# Altium schematic sheet CDU_Peripherals.SchDoc(CDU_Peripherals)
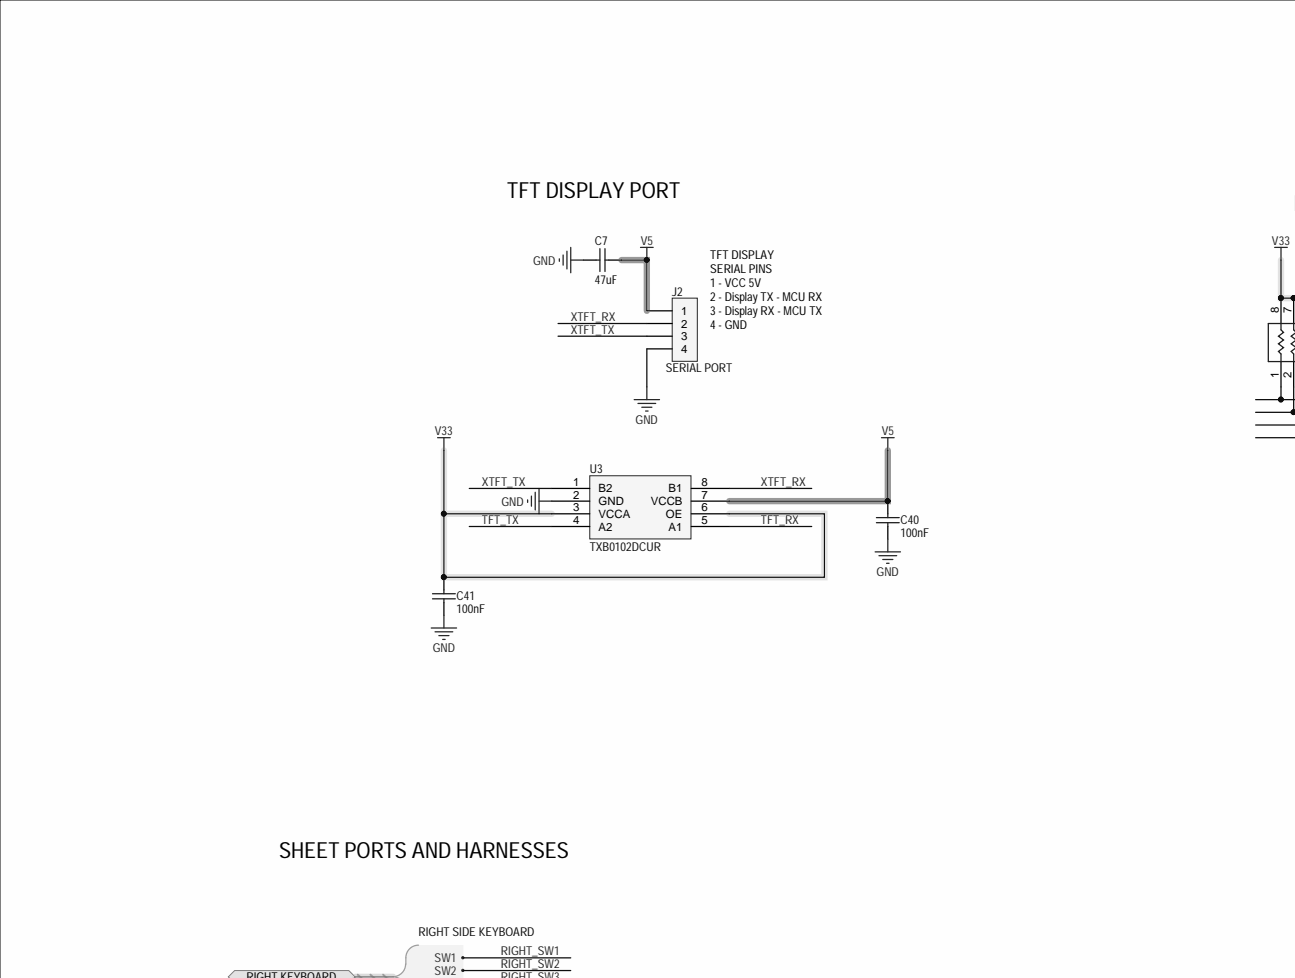
[diagram p0: CDU_Peripherals.SchDoc, top left]
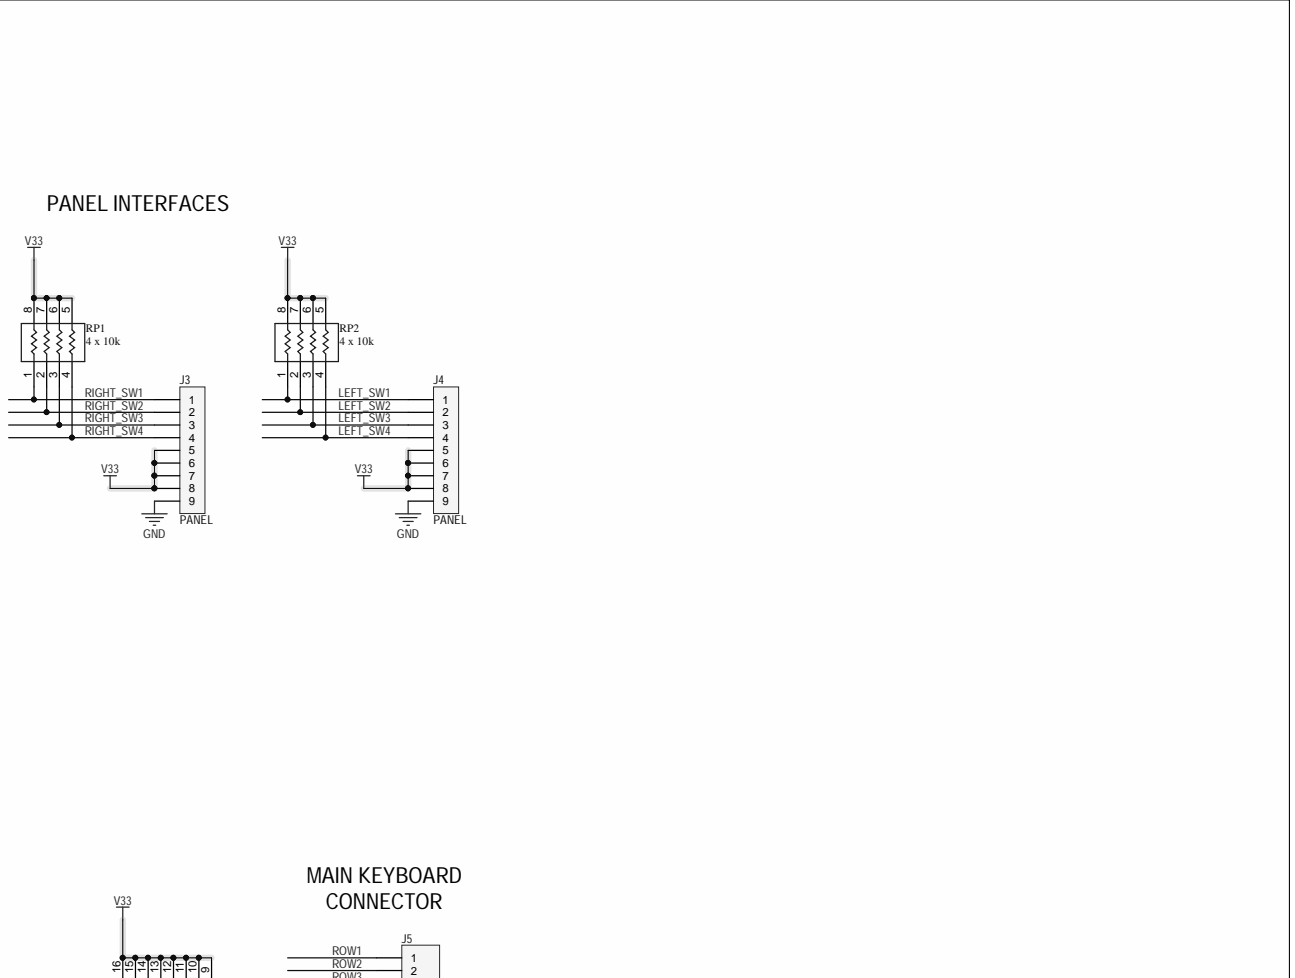
[diagram p1: CDU_Peripherals.SchDoc, top right]
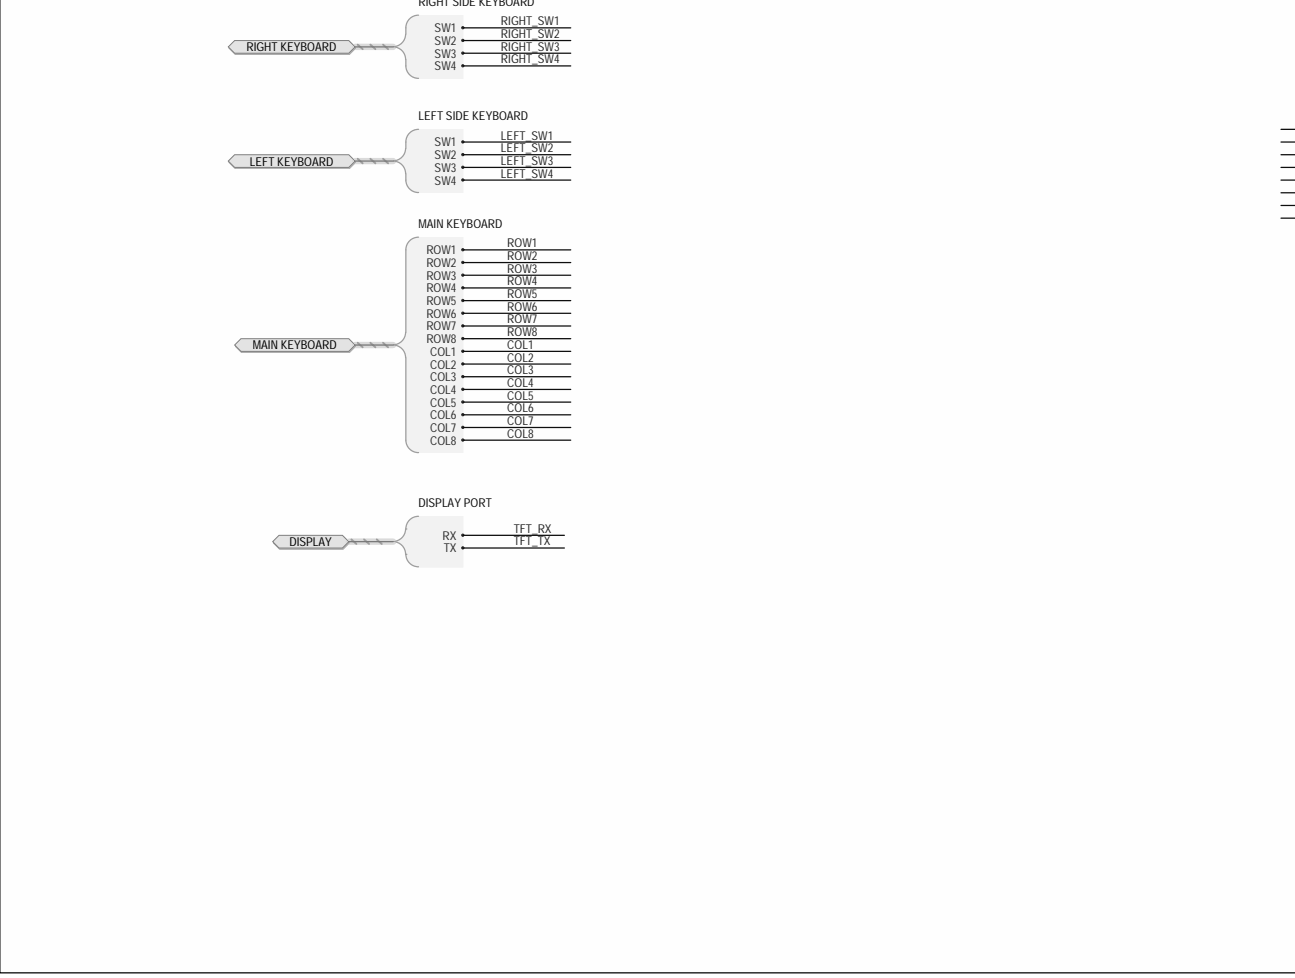
[diagram p2: CDU_Peripherals.SchDoc, bottom left]
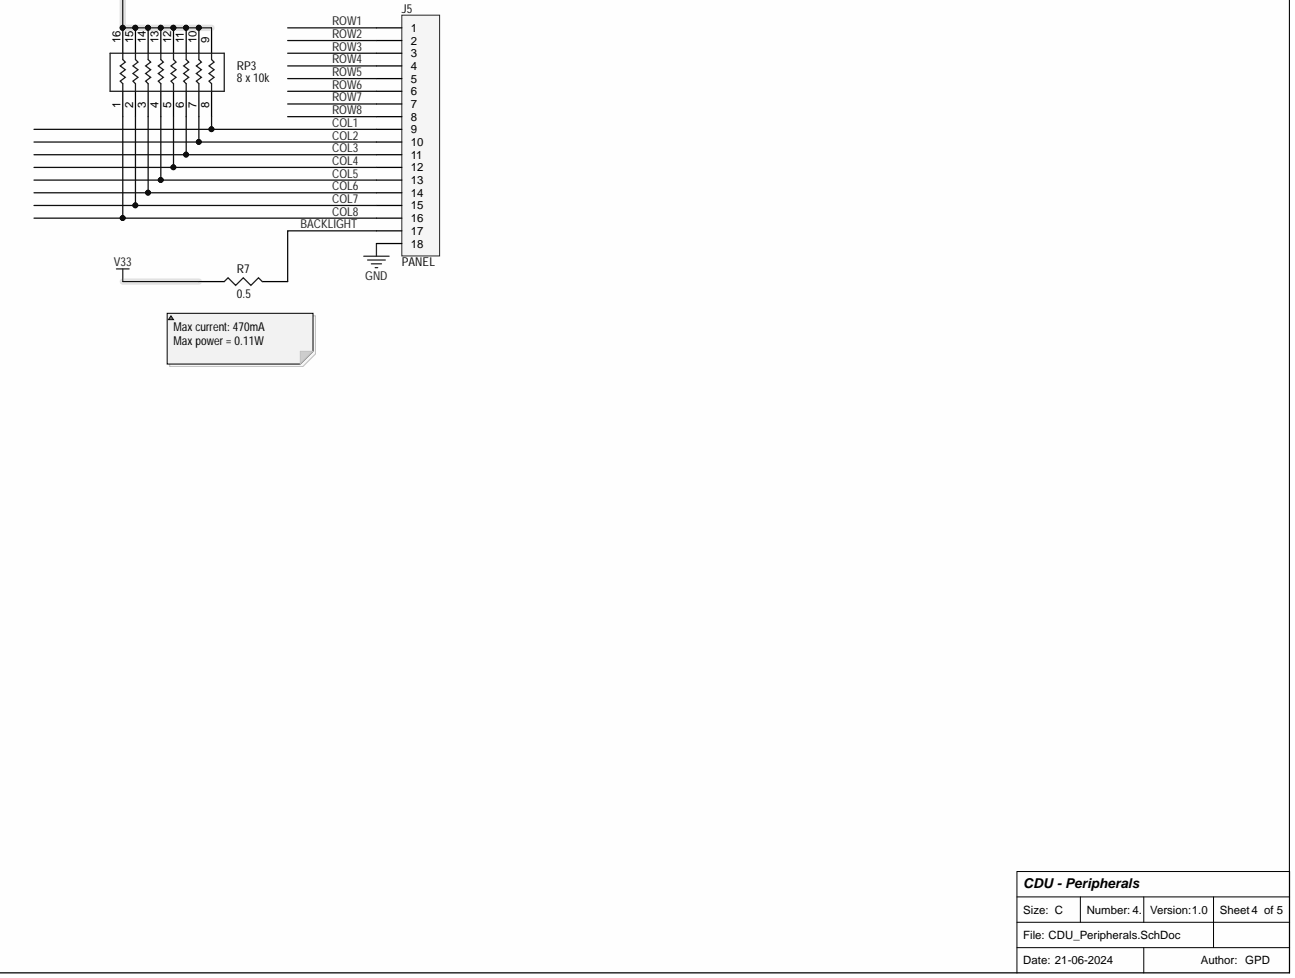
[diagram p3: CDU_Peripherals.SchDoc, bottom right]

## Parts
C7  47uF  [C1210]
C40  100nF  [C0402]
C41  100nF  [C0402]
J2  SERIAL PORT  [HDR1X4]
J3  PANEL  [JST-9P]
J4  PANEL  [JST-9P]
J5  PANEL  [HDR1X18]
R7  0.5  [R1206]
RP1  4 x 10k  [RESPACK08_0603]
RP2  4 x 10k  [RESPACK08_0603]
RP3  8 x 10k  [RESPACK16_0402]
U3  TXB0102DCUR  [PDSO-G8_p0.5MM]

## Ports
DISPLAY, DISPLAY.RX, DISPLAY.TX, LEFT KEYBOARD, LEFT KEYBOARD.SW1, LEFT KEYBOARD.SW2, LEFT KEYBOARD.SW3, LEFT KEYBOARD.SW4, MAIN KEYBOARD, MAIN KEYBOARD.COL1, MAIN KEYBOARD.COL2, MAIN KEYBOARD.COL3, MAIN KEYBOARD.COL4, MAIN KEYBOARD.COL5, MAIN KEYBOARD.COL6, MAIN KEYBOARD.COL7, MAIN KEYBOARD.COL8, MAIN KEYBOARD.ROW1, MAIN KEYBOARD.ROW2, MAIN KEYBOARD.ROW3, MAIN KEYBOARD.ROW4, MAIN KEYBOARD.ROW5, MAIN KEYBOARD.ROW6, MAIN KEYBOARD.ROW7, MAIN KEYBOARD.ROW8, RIGHT KEYBOARD, RIGHT KEYBOARD.SW1, RIGHT KEYBOARD.SW2, RIGHT KEYBOARD.SW3, RIGHT KEYBOARD.SW4

## Nets
GND: C7.1 C40.2 C41.2 J2.4 J3.9 J4.9 J5.18 U3.2(GND)
V5: C7.2 C40.1 J2.1 U3.7(VCCB)
V33: C41.1 J3.5 J3.6 J3.7 J3.8 J4.5 J4.6 J4.7 J4.8 R7.1 RP1.5 RP1.6 RP1.7 RP1.8 RP2.5 RP2.6 RP2.7 RP2.8 RP3.9 RP3.10 RP3.11 RP3.12 RP3.13 RP3.14 RP3.15 RP3.16 U3.3(VCCA) U3.6(OE)
BACKLIGHT: J5.17 R7.2
COL1: J5.9 RP3.8 ; port MAIN KEYBOARD
COL2: J5.10 RP3.7 ; port MAIN KEYBOARD
COL3: J5.11 RP3.6 ; port MAIN KEYBOARD
COL4: J5.12 RP3.5 ; port MAIN KEYBOARD
COL5: J5.13 RP3.4 ; port MAIN KEYBOARD
COL6: J5.14 RP3.3 ; port MAIN KEYBOARD
COL7: J5.15 RP3.2 ; port MAIN KEYBOARD
COL8: J5.16 RP3.1 ; port MAIN KEYBOARD
LEFT_SW1: J4.1 RP2.1 ; port LEFT KEYBOARD
LEFT_SW2: J4.2 RP2.2 ; port LEFT KEYBOARD
LEFT_SW3: J4.3 RP2.3 ; port LEFT KEYBOARD
LEFT_SW4: J4.4 RP2.4 ; port LEFT KEYBOARD
RIGHT_SW1: J3.1 RP1.1 ; port RIGHT KEYBOARD
RIGHT_SW2: J3.2 RP1.2 ; port RIGHT KEYBOARD
RIGHT_SW3: J3.3 RP1.3 ; port RIGHT KEYBOARD
RIGHT_SW4: J3.4 RP1.4 ; port RIGHT KEYBOARD
ROW1: J5.1 ; port MAIN KEYBOARD
ROW2: J5.2 ; port MAIN KEYBOARD
ROW3: J5.3 ; port MAIN KEYBOARD
ROW4: J5.4 ; port MAIN KEYBOARD
ROW5: J5.5 ; port MAIN KEYBOARD
ROW6: J5.6 ; port MAIN KEYBOARD
ROW7: J5.7 ; port MAIN KEYBOARD
ROW8: J5.8 ; port MAIN KEYBOARD
TFT_RX: U3.5(A1) ; port DISPLAY
TFT_TX: U3.4(A2) ; port DISPLAY
XTFT_RX: J2.2 U3.8(B1)
XTFT_TX: J2.3 U3.1(B2)

## Library data of the parts
C7 = CAP: Multilayer Ceramic Capacitor, 47 uF, 16 V, ± 10%, X5R, 1210 [3225 Metric]; Manufacturer Part Number: CL32A476KOJNNNE; Manufacturer: Samsung; Value: 47uF; Supplier Part Number 3: 1276-3376-1-ND; Supplier Part Number 2: C2932477; Supplier Part Number 1: 187-CL32A476KOJNNNE; Supplier 3: Digikey; Supplier 2: LCSC; Supplier 1: Mouser; Library Name: Miscellaneous Devices.SchLib; Component Kind: Standard
C40 C41 = CAP: 100nF ±10% 16V X7R 0402 Multilayer Ceramic Capacitors MLCC - SMD/SMT RoHS; Manufacturer Part Number: C0402C104K4RAC-TU; Manufacturer: KEMET; Value: 100nF; Supplier Part Number 3: 399-C0402C104K4RAC7867DKR-ND; Supplier Part Number 2: 8015145; Supplier Part Number 1: 80-C0402C104K4R; Supplier 3: Digikey; Supplier 2: Rs; Supplier 1: Mouser; Library Name: Miscellaneous Devices.SchLib; Component Kind: Standard
J2 = CON4: Header Assembly 4 Position MTA100 SPCL BEND MTA-100 Series; Manufacturer Part Number: 644874-4; Manufacturer: TE Connectivity; Value: SERIAL PORT; Supplier Part Number 2: A114209-ND; Supplier Part Number 1: 571-644874-4; Supplier 2: Digikey; Supplier 1: Mouser; Library Name: Miscellaneous Devices.SchLib; Component Kind: Standard
J3 J4 = CON9: Connector Header, PH Series, 9 Position, 2.00mm, Through Hole, Press-Fit, Solder, Natural; Manufacturer Part Number: B9B-PH-K-S(LF)(SN); Manufacturer: JST; Value: PANEL; Supplier Part Number 3: 8201453; Supplier Part Number 2: 9491872; Supplier Part Number 1: C157968; Supplier 3: Rs; Supplier 2: Farnell; Supplier 1: LCSC; Library Name: Miscellaneous Devices.SchLib; Component Kind: Standard
J5 = CON18: Conn Unshrouded Header HDR 18 POS 2.54mm Solder ST Thru-Hole Bag; Manufacturer Part Number: 77311-127-18LF; Manufacturer: Amphenol ICC / FCI; Value: PANEL; Supplier Part Number 3: C19190490; Supplier Part Number 2: 649-77311-127-18LF; Supplier Part Number 1: 77311-127-18LF-ND; Supplier 3: LCSC; Supplier 2: Mouser; Supplier 1: Digikey; Library Name: Miscellaneous Devices.SchLib; Component Kind: Standard
R7 = RES1: Res Thick Film 1206 0.5 Ohm 1% 1/2W ±100ppm/°C Molded SMD SMD Paper T/R; Manufacturer Part Number: CSR1206FTR500; Manufacturer: Stackpole Electronics; Value: 0.5; Supplier Part Number 3: CSR1206FTR500TR-ND; Supplier Part Number 2: 708-CSR1206FTR500; Supplier Part Number 1: C346764; Supplier 3: Digikey; Supplier 2: Mouser; Supplier 1: LCSC; Component Kind: Standard
RP1 RP2 = RES_ARRAY4: Resistor array x 4 , isolated; Manufacturer Part Number: YC164-JR-0710KL; Manufacturer: Yageo; Value: 4 x 10k; Supplier Part Number 3: YC164J-10KDKR-ND; Supplier Part Number 2: 603-YC164-JR-0710KL; Supplier Part Number 1: C107374; Supplier 3: Digikey; Supplier 2: Mouser; Supplier 1: LCSC; Component Kind: Standard; Library Name: Gonzalo.IntLib
RP3 = RESPACK3: Res Thick Film Array 10K Ohm 5% 1/4W ±200ppm/°C ISOL Molded 16-Pin 1506(8 X 0402) Convex SMD Punched Carrier T/R; Manufacturer Part Number: EXB-2HV103JV; Manufacturer: Panasonic; Value: 8 x 10k; Supplier Part Number 3: Y1103DKR-ND; Supplier Part Number 2: C713454; Supplier Part Number 1: 667-EXB-2HV103JV; Supplier 3: Digikey; Supplier 2: LCSC; Supplier 1: Mouser; Library Name: Miscellaneous Devices.SchLib; Component Kind: Standard
U3 = PIC12F629/675: 2-Bit Bidirectional Voltage-Level Shifter with Auto Direction Sensing and +/-15-kV ESD Protect 8-VSSOP -40 to 85; Manufacturer: Texas Instruments; Value: TXB0102DCUR; Supplier Part Number 3: TXB0102DCUR; Supplier Part Number 2: 296-22862-6-ND; Supplier Part Number 1: C60709; Supplier 3: Texas Instruments; Supplier 2: Digikey; Supplier 1: LCSC; Manufacturer Part Number: TXB0102DCUR; Library Name: PICs.SchLib; ComponentLink1URL: https://www.ti.com/lit/ds/symlink/txb0102.pdf; ComponentLink1Description: Datasheet; Component Kind: Standard
